# Altium schematic sheet 09 Serial Port_RS485_CAN_Debug Console.SchDoc
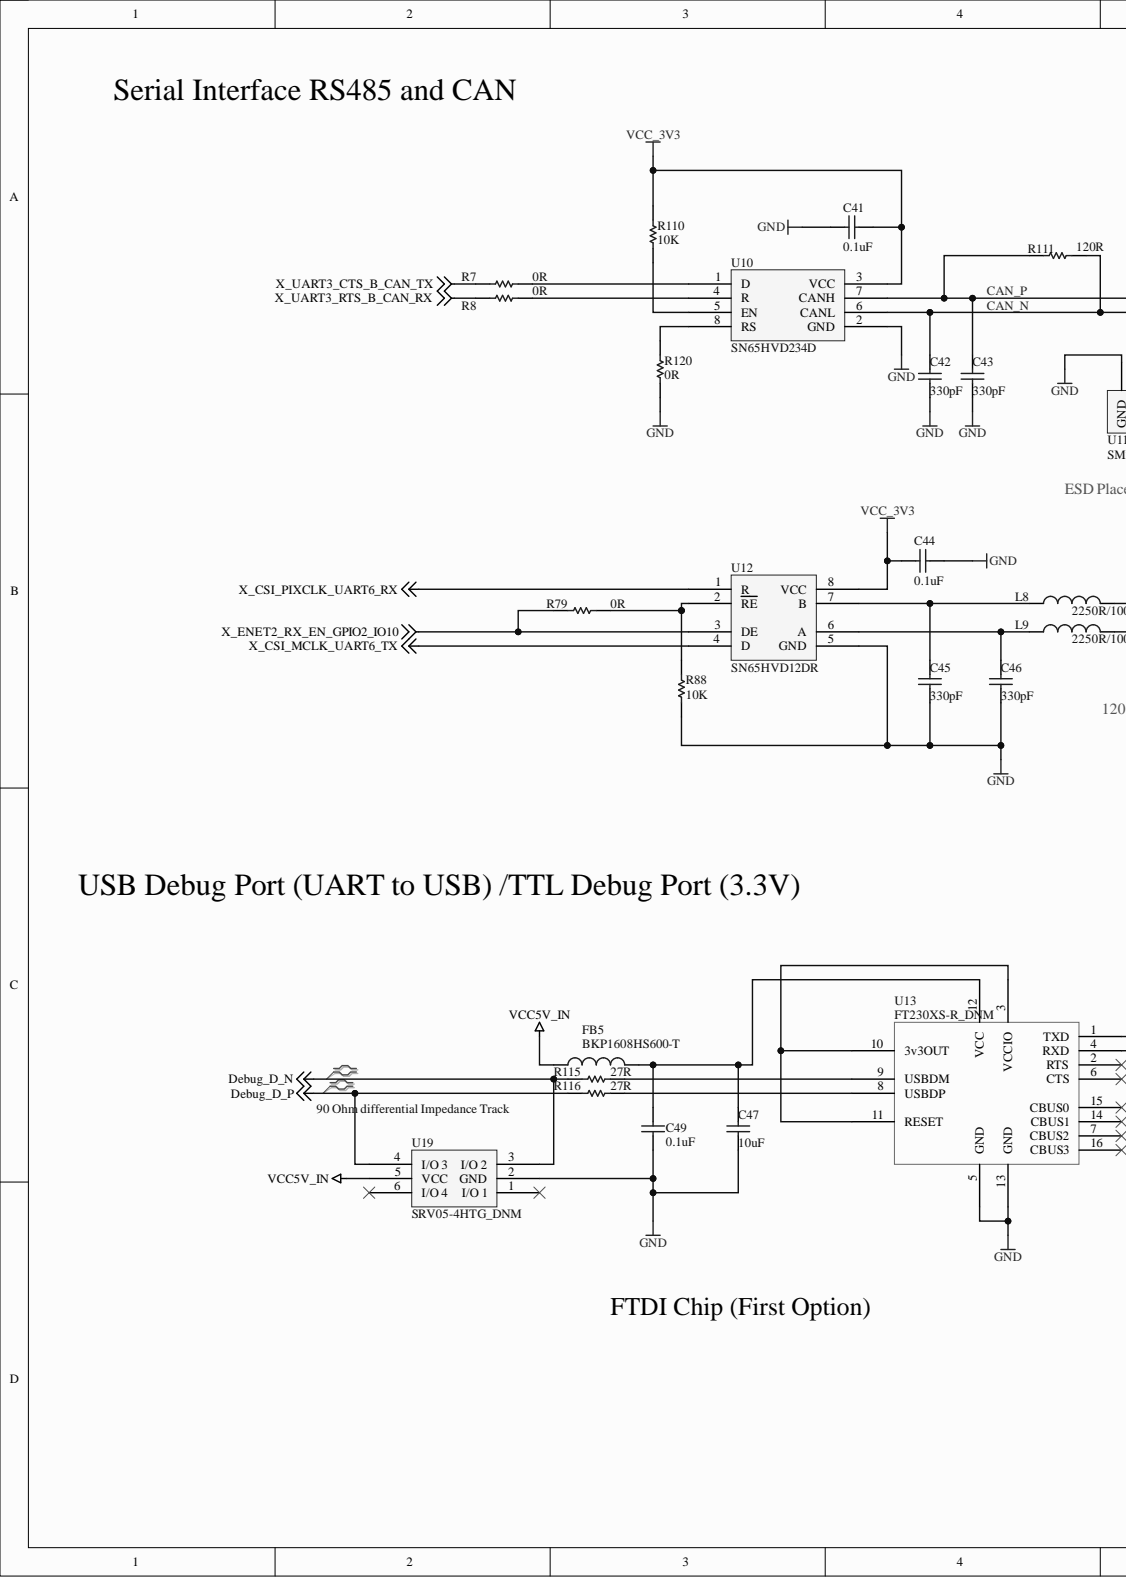
[diagram p0: 09 Serial Port_RS485_CAN_Debug Console.SchDoc, left]
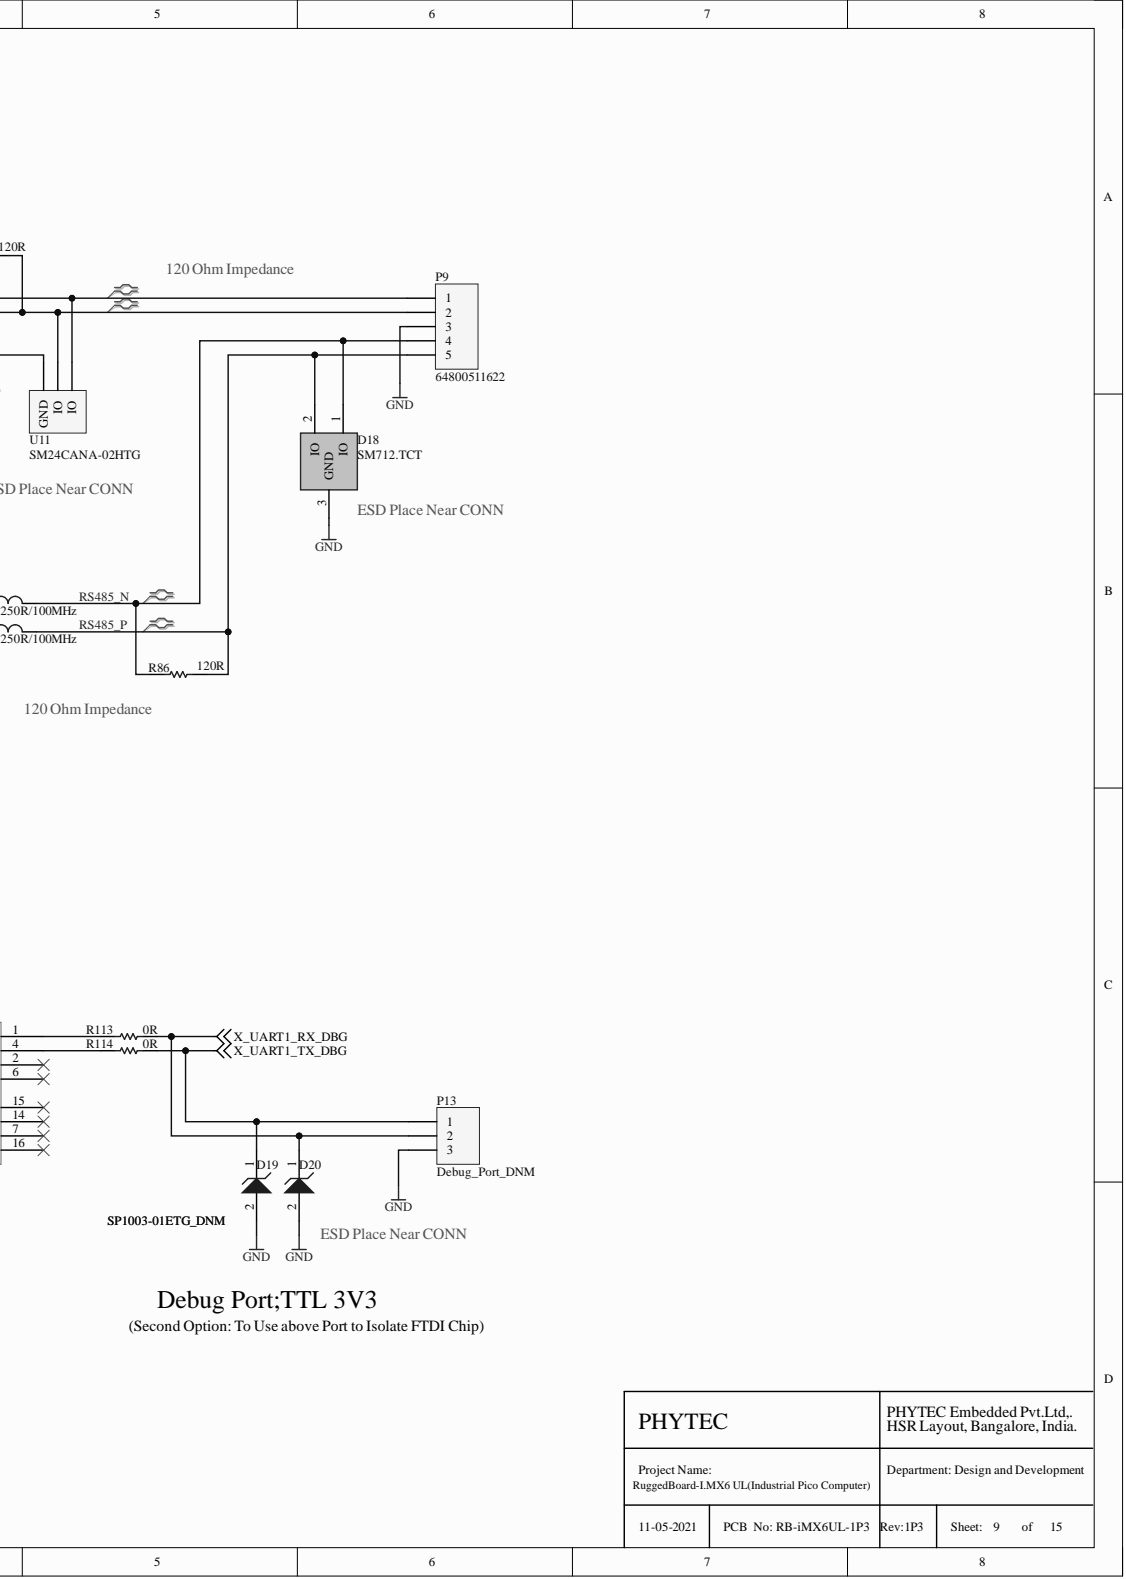
[diagram p1: 09 Serial Port_RS485_CAN_Debug Console.SchDoc, right]

## Parts
C41  0.1uF  [c0402]
C42  330pF  [C0402]
C43  330pF  [C0402]
C44  0.1uF  [C0402]
C45  330pF  [C0402]
C46  330pF  [C0402]
C47  10uF  [C0603]
C49  0.1uF  [C0402]
D18  SM712.TCT  [SOT23_3X2_75P]
D19  SP1003-01ETG_DNM  [SOD882]
D20  SP1003-01ETG_DNM  [SOD882]
FB5  BKP1608HS600-T  [L0603]
L8  2250R/100MHz  [L0805]
L9  2250R/100MHz  [L0805]
P9  64800511622  [HEADER_15P_1X5N]
P13  Debug_Port_DNM  [Header_254P-3N]
R7  0R  [R0402]
R8  0R  [R0402]
R79  0R  [R0402]
R86  120R  [R0603]
R88  10K  [R0402]
R110  10K  [R0402]
R111  120R  [R0603]
R113  0R  [R0402]
R114  0R  [R0402]
R115  27R  [R0402]
R116  27R  [R0402]
R120  0R  [R0402]
U10  SN65HVD234D  [SOIC_127P_600X175_8P]
U11  SM24CANA-02HTG  [SOT95P230X110-3N]
U12  SN65HVD12DR  [SOIC_127P_600X175_8P]
U13  FT230XS-R_DNM  [SSOP16_5MMX6MM_25P]
U19  SRV05-4HTG_DNM  [SOT95P280X145-6N]

## Nets
Debug_D_N: R115.2 U19.3(I/O_2)
Debug_D_P: R116.2 U19.4(I/O_3)
GND: C41.1 C42.1 C43.1 C44.1 C45.1 C46.1 C47.1 C49.1 D18.3 D19.2 D20.2 P9.3 P13.3 R88.2 R120.2 U10.2(GND) U11.3 U12.5(GND) U13.5(GND) U13.13(GND) U19.2(GND)
VCC5V_IN: FB5.1 U19.5(VCC)
VCC_3V3: C41.2 C44.2 R110.1 U10.3(VCC) U12.8(VCC)
X_CSI_MCLK_UART6_TX: U12.4(D)
X_CSI_PIXCLK_UART6_RX: U12.1(R)
X_ENET2_RX_EN_GPIO2_IO10: R79.1 U12.3(DE)
X_UART1_RX_DBG: D20.1 P13.2 R113.1
X_UART1_TX_DBG: D19.1 P13.1 R114.1
X_UART3_CTS_B_CAN_TX: R7.1
X_UART3_RTS_B_CAN_RX: R8.1
CAN_N: C42.2 P9.2 R111.2 U10.6(CANL) U11.2
CAN_P: C43.2 P9.1 R111.1 U10.7(CANH) U11.1
RS485_N: D18.1 L8.2 P9.4 R86.1
RS485_P: D18.2 L9.2 P9.5 R86.2
NetC45_2: C45.2 L8.1 U12.7(B)
NetC46_2: C46.2 L9.1 U12.6(A)
NetC47_2: C47.2 C49.2 FB5.2 U13.12(VCC)
NetR7_2: R7.2 U10.1(D)
NetR8_2: R8.2 U10.4(R)
NetR79_2: R79.2 R88.1 U12.2(RE)
NetR110_2: R110.2 U10.5(EN)
NetR113_2: R113.2 U13.1(TXD)
NetR114_2: R114.2 U13.4(RXD)
NetR115_1: R115.1 U13.9(USBDM)
NetR116_1: R116.1 U13.8(USBDP)
NetR120_1: R120.1 U10.8(RS)
NetU13_3: U13.3(VCCIO) U13.10(3v3OUT) U13.11(RESET)

Not connected: U13 2(RTS) 6(CTS) 7(CBUS2) 14(CBUS1) 15(CBUS0) 16(CBUS3); U19 1(I/O_1) 6(I/O_4)

## Library data of the parts
C41 = Cap: CAP CER 0.1UF 50V X7R 0402; ASSEMBLY_TYPE: SMT; MFG: Murata; MFG_PN: GRM155R71H104ME14D; Component Kind: Standard; Library Name: Miscellaneous Devices.IntLib; PACKAGE: 0402; Signal Integrity: Cap; Simulation: CAP
C42 C43 C45 C46 = Cap: CAP CER 330PF 50V NP0 0402; ASSEMBLY_TYPE: SMT; MFG: Murata; MFG_PN: GRM1555C1H331JA01D; Component Kind: Standard; Library Name: Miscellaneous Devices.IntLib; PACKAGE: 0402; Signal Integrity: Cap; Simulation: CAP
C44 = Cap: CAP CER 0.1UF 50V X7R 0402; ASSEMBLY_TYPE: SMT; MACRO: Mini PCIe CONN; MFG: Murata; MFG_PN: GRM155R71H104ME14D; Component Kind: Standard; Library Name: Miscellaneous Devices.IntLib; PACKAGE: 0402; Signal Integrity: Cap; Simulation: CAP
C47 = Cap: CAP CER 10UF 16V X5R 0603; ASSEMBLY_TYPE: SMT; MACRO: Mini PCIe CONN; MFG: Murata; MFG_PN: GRM188R61C106KAALD; Component Kind: Standard; Library Name: Miscellaneous Devices.IntLib; PACKAGE: 0603
C49 = Cap: CAP CER 0.1UF 50V X7R 0402; ASSEMBLY_TYPE: SMT; MACRO: Power Management (VYS, 5V, 3.3V and Reset); MFG: Murata; MFG_PN: GRM155R71H104ME14D; Component Kind: Standard; Library Name: Miscellaneous Devices.IntLib; PACKAGE: 0402; Signal Integrity: Cap; Simulation: CAP; TEMPERATURE: -55°C ~ 125°C
D18 = BAT54C: TVS DIODE 12V 31V SOT23-3; ASSEBMLY_TYPE: SMD; MFG: Littelfuse Inc.; MFG_PN: SM712-02HTG; Component Kind: Standard; PACKAGE: SOT23-3; Library Name: HARMAN_CARRIER IOT BOARD.SCHLIB
D19 D20 = D Zener: TVS DIODE 5V 12V SOD882; ASSEBMLY_TYPE: SMD; MFG: Littelfuse Inc.; Component Kind: Standard; Library Name: Miscellaneous Devices.IntLib; MFG_PN: SP1003-01ETG; PACKAGE: SOD882
FB5 = Inductor: FERRITE BEAD 60 OHM 0603 1LN; ASSEMBLY_TYPE: SMT; MACRO: USB TO UART_TTL CONVERTOR; MFG: Taiyo Yuden; Component Kind: Standard; Library Name: Miscellaneous Devices.IntLib; MFG_PN: BKP1608HS600-T; PACKAGE: 0603; Signal Integrity: Inductor; Simulation: INDUCTOR; TEMPERATURE: -55°C ~ 125°C
L8 L9 = Inductor: FERRITE BEAD 2.25 KOHM 0805 1LN; ASSEMBLY_TYPE: SMT; MFG: Murata; MFG_PN: BLM21BD222SN1L_DNM; Component Kind: Standard; Library Name: Miscellaneous Devices.IntLib; PACKAGE: 0805
P9 = Header 5: CONN HEADER ZH TOP 5POS 1.5MM; MFG: WE; Component Kind: Standard; Library Name: Miscellaneous Connectors.IntLib; MFG_PN: 64800511622
P13 = Header 3: CONN HEADER 3 POS 2.54; ASSEMBLY_TYPE: TH; MFG: Wurth Electronics Inc.; MFG_PN: 61300311121; Component Kind: Standard; Library Name: Miscellaneous Connectors.IntLib; PACKAGE: TH; Signal Integrity: Connector
R7 R8 R79 R120 = Res1: RES SMD 0.0OHM JUMPER 1/16W 0402; ASSEMBLY_TYPE: SMT; MFG: YAGE0; MFG_PN: RC0402JR-070RL; Component Kind: Standard; Library Name: Miscellaneous Devices.IntLib; PACKAGE: 0402; Signal Integrity: Res; Simulation: RESISTOR
R86 R111 = Res1: RES SMD 120 OHM 1% 1/10W 0603; ASSEMBLY_TYPE: SMT; MFG: PEC; MFG_PN: ERJ-3EKF1200V; Component Kind: Standard; Library Name: Miscellaneous Devices.IntLib; PACKAGE: 0603
R88 R110 = Res1: RES SMD 10K OHM 1% 1/16W 0402; ASSEMBLY_TYPE: SMT; MFG: YAGEO; MFG_PN: RC0402FR-0710KL; Component Kind: Standard; Library Name: Miscellaneous Devices.IntLib; PACKAGE: 0402; Signal Integrity: Res; Simulation: RESISTOR
R113 R114 = Res1: RES SMD 0.0OHM JUMPER 1/16W 0402; ASSEMBLY_TYPE: SMT; MFG: YAGEO; MFG_PN: RC0402JR-070RL; Component Kind: Standard; Library Name: Miscellaneous Devices.IntLib; PACKAGE: 0402; Signal Integrity: Res; Simulation: RESISTOR
R115 R116 = Res1: RES SMD 27 OHM 1% 1/16W 0402; ASSEMBLY_TYPE: SMT; MACRO: USB TO UART_TTL CONVERTOR; MFG: YAGEO; MFG_PN: RC0402FR-0727RL; Component Kind: Standard; Library Name: Miscellaneous Devices.IntLib; PACKAGE: 0402; Signal Integrity: Res; Simulation: RESISTOR; TEMPERATURE: -55°C ~ 155°C
U10 = SN65HVD234D: IC CAN TRANSCEIVER 3.3V 8-SOIC; MFG: TI; Component Kind: Standard; MFG_PN: SN65HVD234D; PACKAGE: SMD; Library Name: CARB for HTT_08-Oct-16 (18-Nov 2016).SCHLIB
U11 = Header 3: TVS DIODE 24VWM 50VC SOT23; ASSEBMLY_TYPE: SMT; MFG: LITTLEFUSE; Component Kind: Standard; Library Name: Miscellaneous Connectors.IntLib; MFG_PN: SM24CANA-02HTG; PACKAGE: SMD
U12 = SN65HVD12D: IC RS485 TRANSCEIVER 3.3V 8-SOIC; ASSEMBLY_TYPE: SMD; MFG: TI; Component Kind: Standard; Library Name: TVS i,MX6 Mother Board.SCHLIB; MFG_PN: SN65HVD12DR; PACKAGE: 8-SOIC
U13 = Component_1: IC USB SERIAL BASIC UART 16SSOP; ASSEMBLY_TYPE: SMT; MACRO: USB TO UART_TTL CONVERTOR; MFG: FTDI; Component Kind: Standard; Library Name: FT230XS-R.SchLib; MFG_PN: FT230XS-R; PACKAGE: 16-SSOP; TEMPERATURE: -40°C ~ 85°C
U19 = SRV05-4HTG: TVS DIODE 6V 15V SOT23-6; ASSEMBLY_TYPE: SMD; MFG: Littelfuse; Component Kind: Standard; MFG_PN: SRV05-4HTG; PACKAGE: SOT-23-6; Library Name: PHY_LIBRARY.SchLib
